# Altium schematic sheet 电源.SchDoc
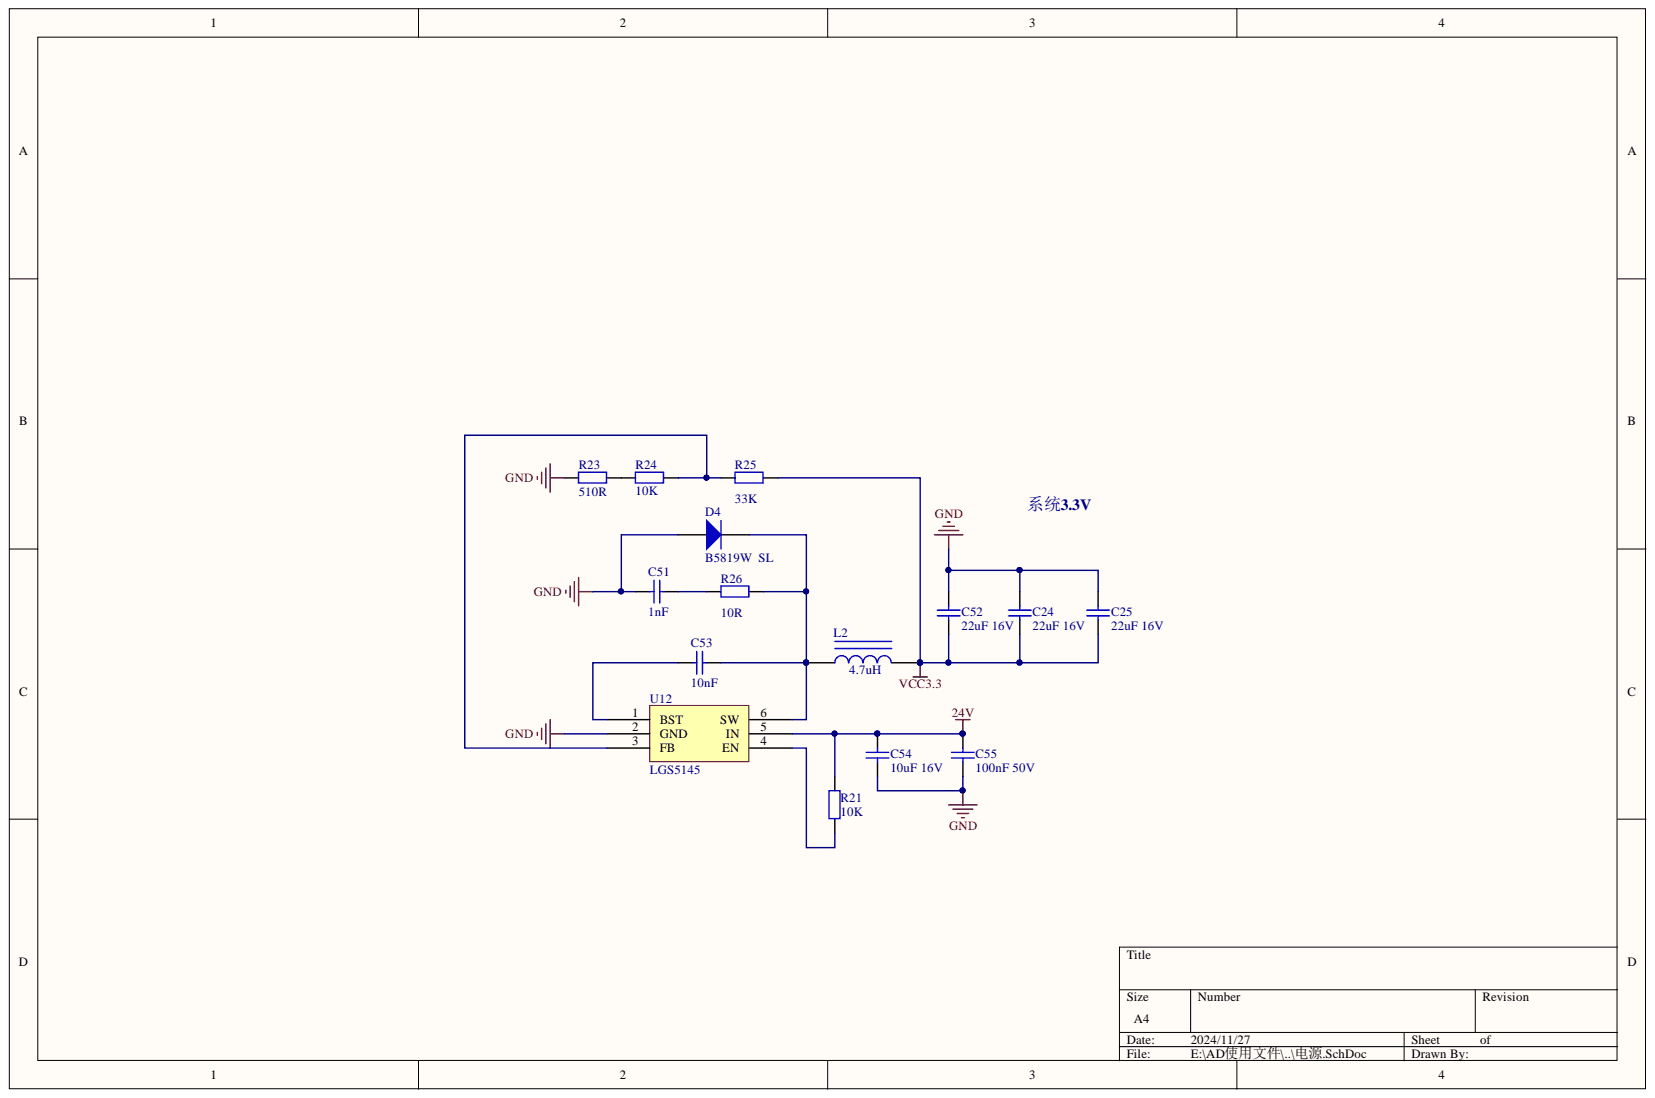
[diagram p0: 电源.SchDoc]

## Parts
C24  22uF 16V  [0805_C]
C25  22uF 16V  [0805_C]
C51  1nF  [0603_C]
C52  22uF 16V  [0805_C]
C53  10nF  [0603_C]
C54  10uF 16V  [0805_C]
C55  100nF 50V  [0603_C]
D4  B5819W  SL  [SOD-123]
L2  4.7uH  [RLF7030]
R21  10K  [0603_R]
R23  510R  [0603_R]
R24  10K  [0603_R]
R25  33K  [0603_R]
R26  10R  [0603_R]
U12  LGS5145  [SOT-23-6]

## Nets
24V: C54.2 C55.2 R21.2 U12.5(IN)
GND: C24.2 C25.2 C51.1 C52.2 C54.1 C55.1 D4.1 R23.1 U12.2(GND)
VCC3.3: C24.1 C25.1 C52.1 L2.2 R25.2
NetC51_2: C51.2 R26.2
NetC53_1: C53.1 U12.1(BST)
NetC53_2: C53.2 D4.2 L2.1 R26.1 U12.6(SW)
NetR21_1: R21.1 U12.4(EN)
NetR23_2: R23.2 R24.1
NetR24_2: R24.2 R25.1 U12.3(FB)

## Library data of the parts
C24 C25 C52 = 0805 22uF (226) 20% 25V: 贴片电容; Component Kind: Standard; ComponentLink1Description: 供应商链接; ComponentLink1URL: http://www.szlcsc.com/product/details_46786.html; Library Name: Cap.SchLib; Package: 0805; Supplier: LC; SuppliersPartNumber: C45783
C51 = 0402 1nF (102) 10% 50V: 贴片电容; Component Kind: Standard; ComponentLink1Description: 供应商链接; ComponentLink1URL: http://www.szlcsc.com/product/details_1875.html; Library Name: Cap.SchLib; Package: 0402; Supplier: LC; SuppliersPartNumber: C1523
C53 = 0402 10nF (103) 10% 50V: 贴片电容; Component Kind: Standard; ComponentLink1Description: 供应商链接; ComponentLink1URL: http://www.szlcsc.com/product/details_15869.html; Library Name: Cap.SchLib; Package: 0402; Supplier: LC; SuppliersPartNumber: C15195
C54 = 0805 10uF (106) 10% 25V: 贴片电容; Component Kind: Standard; ComponentLink1Description: 供应商链接; ComponentLink1URL: http://www.szlcsc.com/product/details_16532.html; Library Name: Cap.SchLib; Package: 0805; Supplier: LC; SuppliersPartNumber: C15850
C55 = 0402 100nF (104) 10% 16V: 贴片电容; Component Kind: Standard; ComponentLink1Description: 供应商链接; ComponentLink1URL: http://www.szlcsc.com/product/details_1877.html; Library Name: Cap.SchLib; Package: 0402; Supplier: LC; SuppliersPartNumber: C1525
D4 = SOD-123 B5819W  SL: 肖特基二极管; Component Kind: Standard; ComponentLink1Description: 供应商链接; ComponentLink1URL: http://www.szlcsc.com/product/details_9093.html; Library Name: Diode.SchLib; Package: SOD-123; Supplier: LC; SuppliersPartNumber: C8598
L2 = 1206 10uH 10%: 贴片电感; Component Kind: Standard; ComponentLink1Description: 供应商链接; ComponentLink1URL: http://www.szlcsc.com/product/details_1403.html; Library Name: IUD.SchLib; Package: 1206; Supplier: LC; SuppliersPartNumber: C1051
R21 = 0402 200KΩ (2003) 1%: 贴片电阻; Component Kind: Standard; ComponentLink1Description: 供应商链接; ComponentLink1URL: http://www.szlcsc.com/product/details_26507.html; Library Name: RES.SchLib; Package: 0402; Supplier: LC; SuppliersPartNumber: C25764
R23 = 0402 300Ω (3000) 1%: 贴片电阻; Component Kind: Standard; ComponentLink1Description: 供应商链接; ComponentLink1URL: http://www.szlcsc.com/product/details_25845.html; Library Name: RES.SchLib; Package: 0402; Supplier: LC; SuppliersPartNumber: C25102
R24 = 0402 7.5KΩ (7501) 1%: 贴片电阻; Component Kind: Standard; ComponentLink1Description: 供应商链接; ComponentLink1URL: http://www.szlcsc.com/product/details_26661.html; Library Name: RES.SchLib; Package: 0402; Supplier: LC; SuppliersPartNumber: C25918
R25 = 0402 49.9KΩ (4992) 1%: 贴片电阻; Component Kind: Standard; ComponentLink1Description: 供应商链接; ComponentLink1URL: http://www.szlcsc.com/product/details_26640.html; Library Name: RES.SchLib; Package: 0402; Supplier: LC; SuppliersPartNumber: C25897
R26 = 0805 200KΩ (2003) 1%: 贴片电阻; Component Kind: Standard; ComponentLink1Description: 供应商链接; ComponentLink1URL: http://www.szlcsc.com/product/details_18227.html; Library Name: RES.SchLib; Package: 0805; Supplier: LC; SuppliersPartNumber: C17539
U12 = MP2359DJ-LF-Z_[C14259]: DC-DC芯片; Component Kind: Standard; ComponentLink1Description: 供应商链接; ComponentLink1URL: http://www.szlcsc.com/product/details_14917.html; Library Name: IC.SchLib; Package: SOT-23-6; Supplier: LC; SuppliersPartNumber: C14259
